# Altium schematic sheet overview.SchDoc
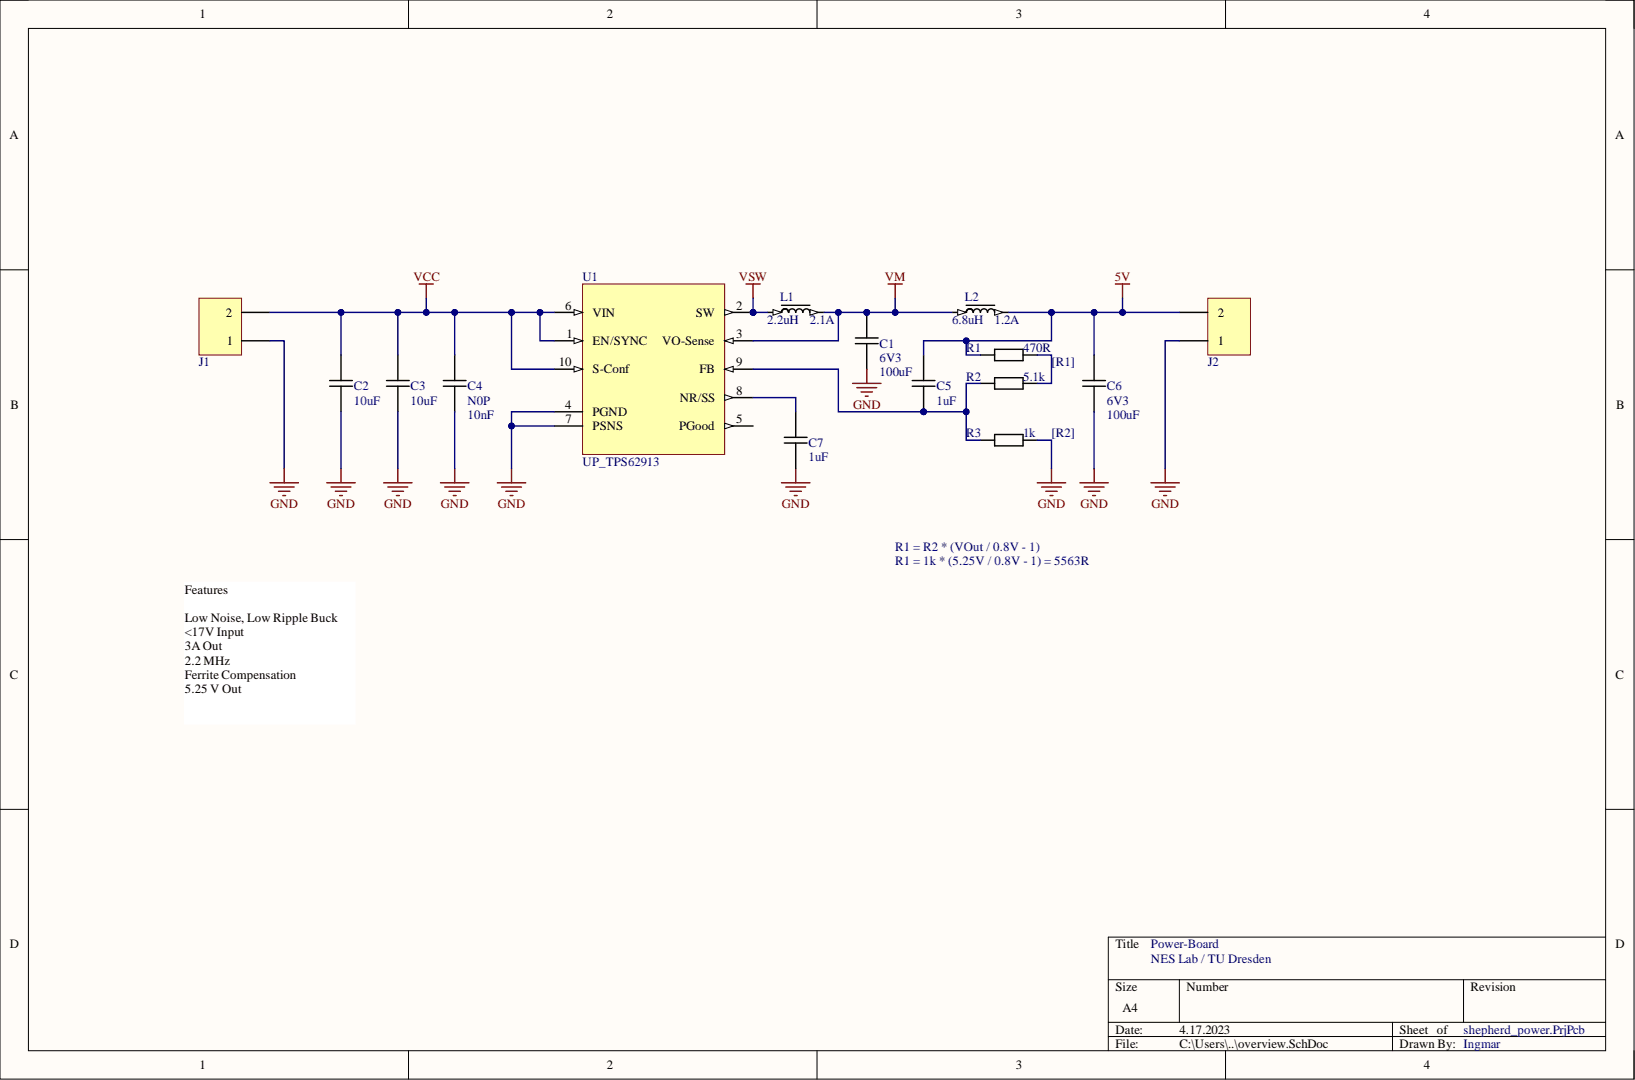
[diagram p0: overview.SchDoc]

## Parts
C1  value 100uF  V_max: 6V3  [CAPC3216X18]  (hidden comment: C_1206_100uF_6V3)
C2  value 10uF  [CAPC1608X09]  (hidden comment: C_0603_10uF_16V)
C3  value 10uF  [CAPC1608X09]  (hidden comment: C_0603_10uF_16V)
C4  value 10nF  Dielectric: N0P  [CAPC1005X06]  (hidden comment: C_0402_10nF_25V_NP0)
C5  value 1uF  [CAPC1608X09]  (hidden comment: C_0603_1uF_10V)
C6  value 100uF  V_max: 6V3  [CAPC3216X18]  (hidden comment: C_1206_100uF_6V3)
C7  value 1uF  [CAPC1608X09]  (hidden comment: C_0603_1uF_10V)
J1  J_T1x2_3.5mm_Screw  [P_Phoenix_1984617]  (value not drawn)
J2  J_T1x2_3.5mm_Screw  [P_Phoenix_1984617]  (value not drawn)
L1  value 2.2uH  I_max: 2.1A  [INDP4040X25N]  (hidden comment: L_SRN4026-2R2Y)
L2  value 6.8uH  I_max: 1.2A  [INDP4040X25N]  (hidden comment: L_SRN4026-6R8M)
R1  value 470R  [RESC1005X04]  (hidden comment: R_0402_470R)
R2  value 5.1k  [RESC1005X04]  (hidden comment: R_0402_5k1_1%)
R3  value 1k  [RESC1005X04]  (hidden comment: R_0402_1k)
U1  UP_TPS62913  [VQFN50P200X200X100_HS-10N]

## Nets
5V: C5.2 C6.2 J2.2 L2.2 R1.2
GND: C1.1 C2.1 C3.1 C4.1 C6.1 C7.1 J1.1 J2.1 R3.2 U1.4(PGND) U1.7(PSNS)
VCC: C2.2 C3.2 C4.2 J1.2 U1.1(EN/SYNC) U1.6(VIN) U1.10(S-Conf)
VM: C1.2 L1.2 L2.1 U1.3(VO-Sense)
VSW: L1.1 U1.2(SW)
NetC5_1: C5.1 R2.2 R3.1 U1.9(FB)
NetC7_2: C7.2 U1.8(NR/SS)
NetR1_1: R1.1 R2.1

Not connected: U1 5(PGood)

## Library data of the parts
C1 C6 = .C_1206_100uF_6V3: Capacitor; Manufacturer: Taiyo Yuden; Manufacturer PN: JMK316ABJ107ML-T; Dielectric: X5R; Tolerance: 20%; Component Kind: Standard; Distributer: Mouser; Distributer PN: 963-JMK316ABJ107ML-T; Price n10 [€]: 0.25; Library Name: shepherd_parts.SchLib
C2 C3 = .C_0603_10uF_16V: Capacitor; Manufacturer: Taiyo Yuden; Manufacturer PN: EMK107BBJ106MA-T; Dielectric: X5R; Tolerance: 20%; V_max: 16V; Component Kind: Standard; Distributer: Mouser; Distributer PN: 963-EMK107BBJ106MA-T; Price n10 [€]: 0.14; Library Name: shepherd_parts.SchLib
C4 = .C_0402_10nF_25V_NP0: Capacitor; Manufacturer: Murata; Manufacturer PN: GRM1555C1E103JE01J; Tolerance: 5%; V_max: 25V; Component Kind: Standard; Distributer: Mouser; Distributer PN: 81-GRM1555C1E103JE1J; Price n10 [€]: 0.15; Library Name: shepherd_parts.SchLib
C5 C7 = .C_0603_1uF_10V: Capacitor; Manufacturer: KEMET; Manufacturer PN: C0603C105K8RACTU; Dielectric: X7R; Tolerance: 10%; V_max: 10V; Component Kind: Standard; Distributer: Mouser; Distributer PN: 80-C0603C105K8R; Price n10 [€]: 0.03; Library Name: shepherd_parts.SchLib
J1 J2 = .J_T1x2_3.5mm_Screw: Fixed Terminal Block, Screw; Manufacturer: Phoenix Contact; Manufacturer PN: 1984617; I_max: 17A; V_max: 160V; Component Kind: Standard; Distributer: Mouser; Distributer PN: 651-1984617; Price n10 [€]: 0.39; Library Name: shepherd_parts.SchLib
L1 = .L_SRN4026-2R2Y: Inductor, fixed, shielded, 29 mOhm; Manufacturer: Bourns; Tolerance: 20; Component Kind: Standard; Distributer: Mouser; Distributer PN: 652-SRN4026-2R2Y; Manufacturer PN: SRN4026-2R2Y; Price n10 [€]: 0.38; Library Name: shepherd_parts.SchLib
L2 = .L_SRN4026-6R8M: Inductor, fixed, shielded, 84 mOhm; Manufacturer: Bourns; Tolerance: 20; Component Kind: Standard; Distributer: Mouser; Distributer PN: 652-SRN4026-6R8M; Manufacturer PN: SRN4026-6R8M; Price n10 [€]: 0.36; Library Name: shepherd_parts.SchLib
R1 = .R_0402_470R_1%: Resistor; Manufacturer: Panasonic; Manufacturer PN: ERJ-2RKF4700X; P_max: 100mW; Tolerance: 1%; V_max: 50V; Component Kind: Standard; Distributer: Mouser; Distributer PN: 667-ERJ-2RKF4700X; Price n10 [€]: 0.028; Library Name: shepherd_parts.SchLib
R2 = .R_0402_5k1_1%: Resistor; Manufacturer: Panasonic; Manufacturer PN: ERJ-2RKF5101X; P_max: 100mW; Tolerance: 1%; V_max: 50V; Component Kind: Standard; Distributer: Mouser; Distributer PN: 667-ERJ-2RKF5101X; Price n10 [€]: 0.02; Library Name: shepherd_parts.SchLib
R3 = .R_0402_1k: Resistor; Manufacturer: Panasonic; Manufacturer PN: ERJ-2GEJ102X; P_max: 100mW; Tolerance: 5%; V_max: 50V; Component Kind: Standard; Distributer: Mouser; Distributer PN: 667-ERJ-2GEJ102X; Price n10 [€]: 0.02; Library Name: shepherd_parts.SchLib
U1 = TPS62913: Low Noise, Low ripple buck converter; Manufacturer: TI; Manufacturer PN: TPS62913RPUR; P_max: 3 A; V_max: 17; V_min: 3; Component Kind: Standard; Distributer: Mouser; Distributer PN: 595-TPS62913RPUR; Price n10 [€]: 2.90; Library Name: shepherd_parts.SchLib
